# Altium schematic sheet NuttyMasterBed.SchDoc
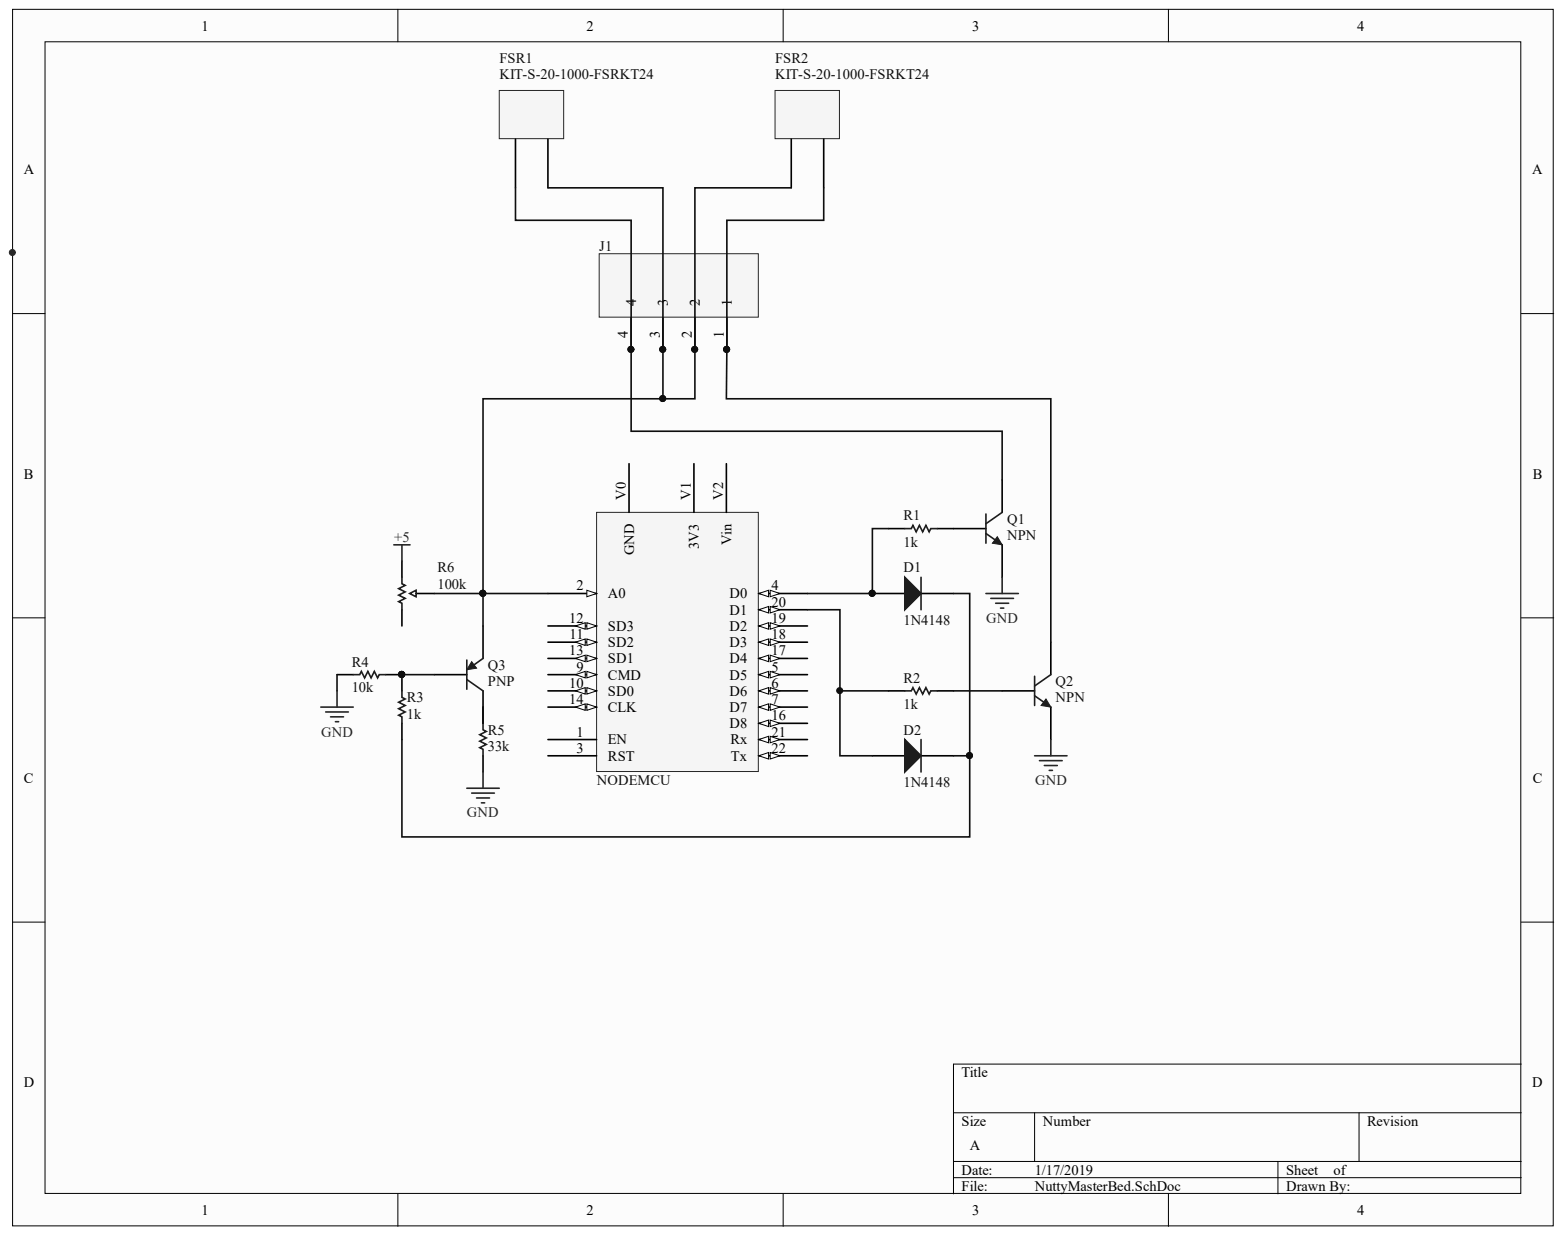
[diagram p0: NuttyMasterBed.SchDoc]

## Parts
D1  1N4148  [PCB-6nky0k1a5muz6qopfpi2-1]
D2  1N4148  [PCB-6nky0k1a5muz6qopfpi2-1]
FSR1  KIT-S-20-1000-FSRKT24
FSR2  KIT-S-20-1000-FSRKT24
J1  (no value)  [PCB-upwyq9hk32om98122kmp-1]
NODEMCU  (no value)  [PCB-uhrtaumuztr3czq6ln40-1]
Q1  NPN  [PCB-o0283o6qgu0z24mrl6ia-1]
Q2  NPN  [PCB-o0283o6qgu0z24mrl6ia-1]
Q3  PNP  [PCB-9939e1hv6bkt2bvysn1x-1]
R1  1k  [PCB-lm2ww5rf4yzedulqsq1l-1]
R2  1k  [PCB-lm2ww5rf4yzedulqsq1l-1]
R3  1k  [PCB-lm2ww5rf4yzedulqsq1l-1]
R4  10k  [PCB-lm2ww5rf4yzedulqsq1l-1]
R5  33k  [PCB-lm2ww5rf4yzedulqsq1l-1]
R6  100k  [PCB-iy8b1q2r9dop8q7y14eu-1]

## Nets
+5: R6.1
GND: Q1.3 Q2.3 R4.1 R5.1
NetD1_1: D1.1 NODEMCU.4(D0) R1.1
NetD1_2: D1.2 D2.2 R3.1
NetD2_1: D2.1 NODEMCU.20(D1) R2.1
NetJ1_1: J1.1 Q2.2
NetJ1_2: J1.2 J1.3 NODEMCU.2(A0) Q3.3 R6.2
NetJ1_4: J1.4 Q1.2
NetQ1_1: Q1.1 R1.2
NetQ2_1: Q2.1 R2.2
NetQ3_1: Q3.1 R3.2 R4.2
NetQ3_2: Q3.2 R5.2

Not connected: FSR1 0 1; FSR2 0 1; NODEMCU 1(EN) 3(RST) 5(D5) 6(D6) 7(D7) 9(CMD) 10(SD0) 11(SD2) 12(SD3) 13(SD1) 14(CLK) 16(D8) 17(D4) 18(D3) 19(D2) 21(Rx) 22(Tx) V0(GND) V1(3V3) V2(Vin); R6 3

## Library data of the parts
D1 D2 = CMP-a1b16bf6a35d7e76-1: SWITCHING DIODE, 100V, 150mA, DO-35; Diode Type: Switching; Diode Case Style: DO-35; No. of Pins: 2; Manufacturer Part Number: 1N4148-TAP; Lead-Free Status: Lead Free; Case/Package: DO-204, DO-35; Component Kind: Standard; Library Name: CommunityVault; Number of Pins: 2; OctopartID: a1b16bf6a35d7e76; Octopart URL: https://octopart.com/1n4148-tap-vishay-55388042; Packaging: Ammo Pack; RoHS: Compliant
FSR1 FSR2 = CMP-e44a2c0459cf3b8d-1: 24 Inch Force Sensing Resistor (FSR) Strip; Manufacturer Part Number: 1696; Number of Outputs: 1; Output Current: 0.00 A (min), 10.0 A; Output Voltage: 1.00 V (min), 20.0 V, 20.0 V (max); Power Rating: 200 W; Component Kind: Standard; Library Name: CommunityVault; OctopartID: e44a2c0459cf3b8d; Octopart URL: https://octopart.com/1696-pololu-21272803; RoHS: Not Applicable; Size-Height: 98.0 mm; Size-Length: 215 mm; Size-Width: 193 mm; Weight: 2.99 kg
J1 = CMP-c6f60d716a211931-1: Conn IDC SSL Connector F 4 POS 4mm Solder RA SMD Pocket Tape; Manufacturer Part Number: 2106003-4; ELV Compliant: Compliant; Gender: Female; Number of Contacts: 4; Color: Yellow; Component Kind: Standard; Contact Material: Copper Alloy; Contact Plating: Tin, Tin over Nickel, Tin Lead; Flammability Rating: UL 94 V-0; Housing Color: Natural; Library Name: CommunityVault; Lifecycle Status: Active; Mounting Style: Surface Mount; OctopartID: c6f60d716a211931; Octopart URL: http://octopart.com/2106003-4-te+connectivity-42830257; Packaging: Tape; REACH SVHC Compliance: No SVHC; RoHS: Compliant
NODEMCU = VMA107: NodeMCU V2 Lua Based ESP8266 Development Board; Component Kind: Standard; Library Name: Octopart library
Q1 Q2 = MJL4302AG: The MJL4281A and MJL4302A are PowerBase power transistors for high power audio.; Component Kind: Standard; Library Name: Octopart library; Manufacturer Part Number: MJL4302AG; OctopartID: 447a8d7514a99bbd; Octopart URL: http://octopart.com/mjl4302ag-on+semiconductor-357813; Packaging: Tube; RoHS: Compliant
Q3 = MJL4302AG: The MJL4281A and MJL4302A are PowerBase power transistors for high power audio.; Current Rating: -15.0 A; Lead-Free Status: Lead Free; Voltage Rating (DC): -350 V; Component Kind: Standard; Library Name: Octopart library; Lifecycle Status: Active; Manufacturer Part Number: MJL4302AG; OctopartID: 447a8d7514a99bbd; Octopart URL: http://octopart.com/mjl4302ag-on+semiconductor-357813; Packaging: Tube; RoHS: Compliant
R1-R5 = 352110KFT: Resistor; Thick Film; Res 10 Kilohms; Pwr-Rtg 2 W; Tol 1%; SMT; 2512; TCR 100 ppm/C; TR; ELV Compliant: Compliant; Resistance: 10.0 kΩ; Component Kind: Standard; Library Name: Octopart library; Manufacturer Part Number: 352110KFT; Mounting Style: Surface Mount; OctopartID: 6095a8f48c47bf52; Packaging: Tape & Reel (TR); RoHS: Compliant
R6 = CMP-a53c6c348fab7afb-1: Trimmer; Cermet; Rest 100 Ohms; PCB; 12 Turns; Pwr-Rtg 0.25W; Power Rating: 250 mW; Resistance Tolerance: ±10%; Voltage Rating (DC): 300 V; Component Kind: Standard; Library Name: CommunityVault; Operating Temperature: -55.0 °C (min); Size-Height: 7.24 mm; Size-Width: 4.50 mm; Weight: 425 mg
